# Altium schematic sheet top.SchDoc(top)
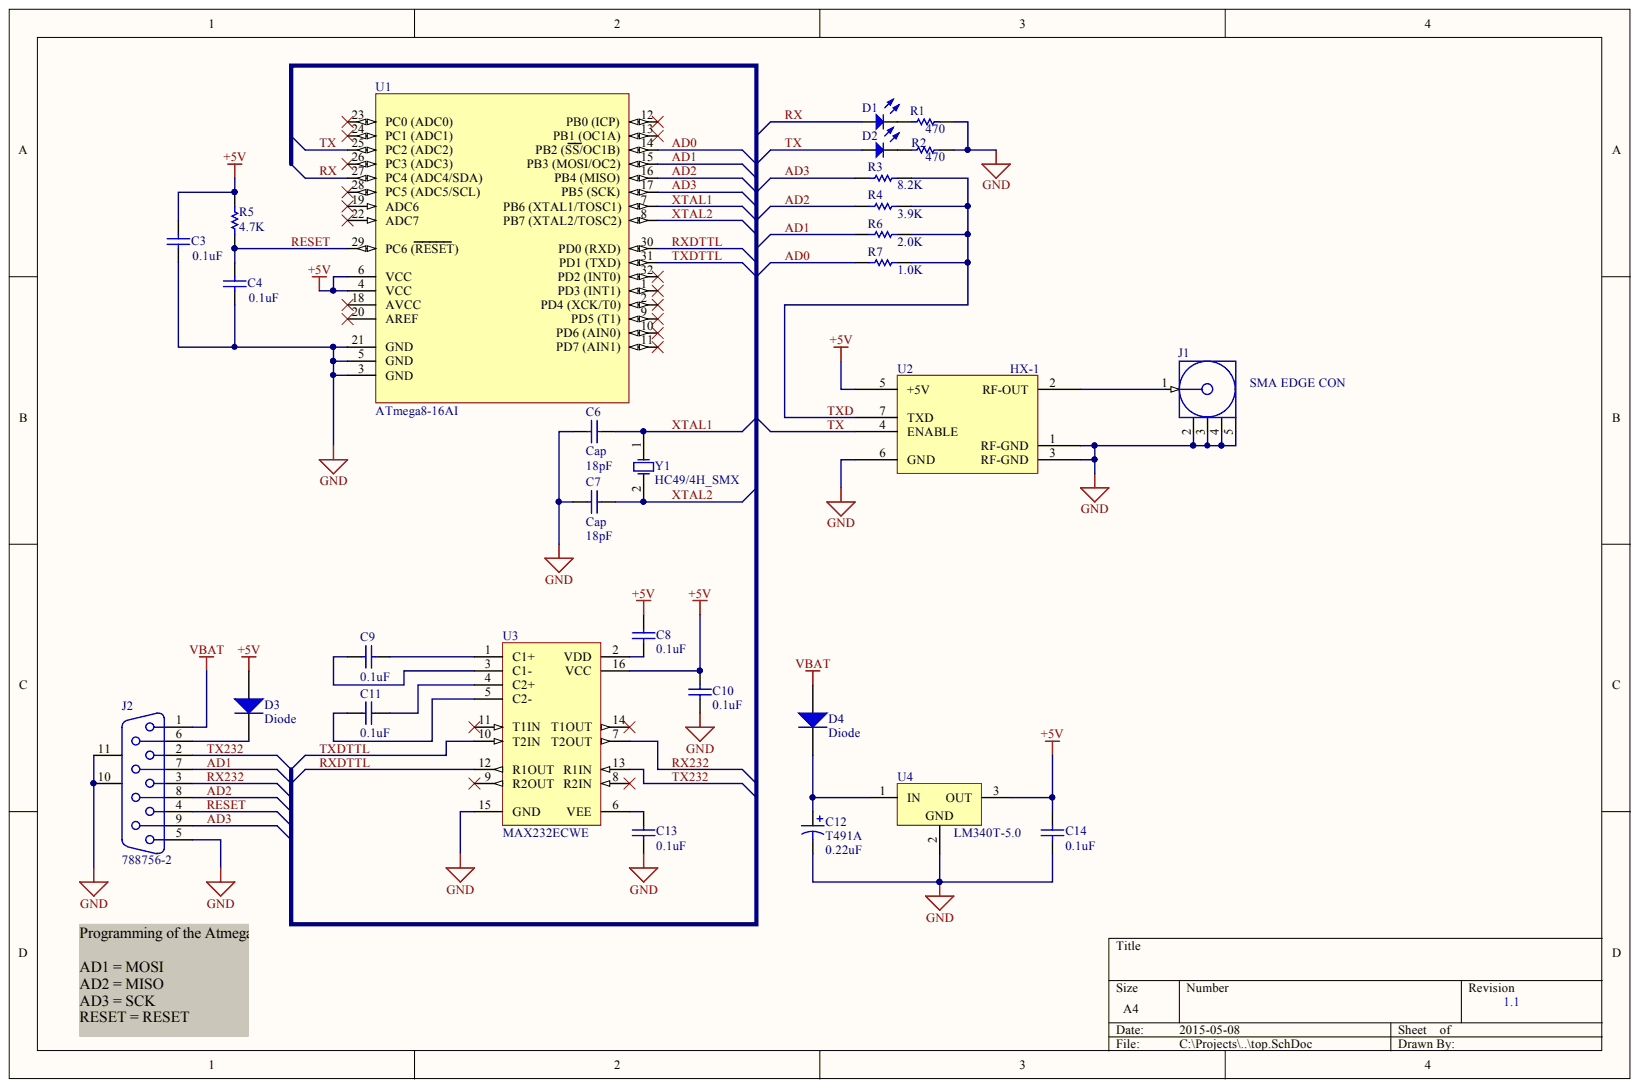
[diagram p0: top.SchDoc]

## Parts
C3  value 0.1uF  [0603]  (hidden comment: Cap Semi)
C4  value 0.1uF  [0603]  (hidden comment: Cap Semi)
C6  Cap  value 18pF  [0603]
C7  Cap  value 18pF  [0603]
C8  value 0.1uF  [0603]  (hidden comment: Cap Semi)
C9  value 0.1uF  [0603]  (hidden comment: Cap Semi)
C10  value 0.1uF  [0603]  (hidden comment: Cap Semi)
C11  value 0.1uF  [0603]  (hidden comment: Cap Semi)
C12  T491A  value 0.22uF  [A]
C13  value 0.1uF  [0603]  (hidden comment: Cap Semi)
C14  value 0.1uF  [0603]  (hidden comment: Cap Semi)
D1  LED3  [0603]  (value not drawn)
D2  LED3  [0603]  (value not drawn)
D3  Diode  [SOD-323]
D4  Diode  [SOD-323]
J1  SMA EDGE CON  [SMAEDGE]
J2  788756-2  [788756-1]
R1  value 470  [0603]  (hidden comment: Res1)
R2  value 470  [0603]  (hidden comment: Res1)
R3  value 8.2K  [0603]  (hidden comment: Res1)
R4  value 3.9K  [0603]  (hidden comment: Res1)
R5  value 4.7K  [0603]  (hidden comment: Res1)
R6  value 2.0K  [0603]  (hidden comment: Res1)
R7  value 1.0K  [0603]  (hidden comment: Res1)
U1  ATmega8-16AI  [32A_N]
U2  HX-1  [HX-1]
U3  MAX232ECWE  [WSO16_N]
U4  LM340T-5.0  [SOT223]
Y1  HC49/4H_SMX  [HC49/4H_SMX]

## Nets
+5V: C3.2 C8.1 C10.2 C14.2 D3.1 R5.1 U1.4(VCC) U1.6(VCC) U2.5(+5V) U3.16(VCC) U4.3
GND: C3.1 C4.1 C6.1 C7.1 C10.1 C12.2 C13.2 C14.1 J1.2 J1.3 J1.4 J1.5 J2.5 J2.10 J2.11 R1.1 R2.1 U1.3(GND) U1.5(GND) U1.21(GND) U2.1(RF-GND) U2.3(RF-GND) U2.6(GND) U3.15(GND) U4.2
VBAT: D4.1 J2.1
AD0: R7.1 U1.14(PB2_(SS/OC1B))
AD1: J2.7 R6.1 U1.15(PB3_(MOSI/OC2))
AD2: J2.8 R4.1 U1.16(PB4_(MISO))
AD3: J2.9 R3.1 U1.17(PB5_(SCK))
RESET: C4.2 J2.4 R5.2 U1.29(PC6_(RESET))
RX: D1.1 U1.27(PC4_(ADC4/SDA))
RX232: J2.3 U3.7(T2OUT)
RXDTTL: U1.30(PD0_(RXD)) U3.12(R1OUT)
TX: D2.1 U1.25(PC2_(ADC2)) U2.4(ENABLE)
TX232: J2.2 U3.13(R1IN)
TXD: R3.2 R4.2 R6.2 R7.2 U2.7(TXD)
TXDTTL: U1.31(PD1_(TXD)) U3.10(T2IN)
XTAL1: C6.2 U1.7(PB6_(XTAL1/TOSC1)) Y1.1
XTAL2: C7.2 U1.8(PB7_(XTAL2/TOSC2)) Y1.2
NetC8_2: C8.2 U3.2(VDD)
NetC9_1: C9.1 U3.1(C1+)
NetC9_2: C9.2 U3.3(C1-)
NetC11_1: C11.1 U3.4(C2+)
NetC11_2: C11.2 U3.5(C2-)
NetC12_1: C12.1 D4.2 U4.1
NetC13_1: C13.1 U3.6(VEE)
NetD1_2: D1.2 R1.2
NetD2_2: D2.2 R2.2
NetD3_2: D3.2 J2.6
NetJ1_1: J1.1 U2.2(RF-OUT)
N00007:

Not connected: U1 1(PD3_(INT1)) 2(PD4_(XCK/T0)) 9(PD5_(T1)) 10(PD6_(AIN0)) 11(PD7_(AIN1)) 12(PB0_(ICP)) 13(PB1_(OC1A)) 18(AVCC) 19(ADC6) 20(AREF) 22(ADC7) 23(PC0_(ADC0)) 24(PC1_(ADC1)) 26(PC3_(ADC3)) 28(PC5_(ADC5/SCL)) 32(PD2_(INT0)); U3 8(R2IN) 9(R2OUT) 11(T1IN) 14(T1OUT)

## Library data of the parts
C3 C4 C8-C11 C13 C14 = Cap Semi: Capacitor (Semiconductor SIM Model); ELFA: 65-759-63; Component Kind: Standard; LatestRevisionDate: 17-Jul-2002; LatestRevisionNote: Re-released for DXP Platform.; Library Name: Miscellaneous Devices.IntLib; PackageReference: C1206; Published: 8-Jun-2000; Signal Integrity: Cap Semi; Simulation: CAP; Publisher: Altium Limited
C6 = Cap: Capacitor; ELFA: 65-750-47; Value: 18pF; Component Kind: Standard; LatestRevisionDate: 17-Jul-2002; LatestRevisionNote: Re-released for DXP Platform.; Library Name: Miscellaneous Devices.IntLib; PackageReference: RAD-0.3; Published: 8-Jun-2000; Signal Integrity: Cap; Simulation: CAP; Publisher: Altium Limited
C7 = Cap: Capacitor; ELFA: 65-750-47; Component Kind: Standard; LatestRevisionDate: 17-Jul-2002; LatestRevisionNote: Re-released for DXP Platform.; Library Name: Miscellaneous Devices.IntLib; PackageReference: RAD-0.3; Published: 8-Jun-2000; Signal Integrity: Cap; Simulation: CAP; Publisher: Altium Limited
C12 = T491A: Solid Tantalum Chip Capacitor, Standard T491 Series - Industrial Grade; ELFA: 67-737-25; Code_JEITA: 3216-18; Component Kind: Standard; ComponentLink1Description: Manufacturer Link; ComponentLink1URL: http://www.kemet.com/; ComponentLink2Description: Datasheet; ComponentLink2URL: http://www.kemet.com/kemet/web/homepage/kechome.nsf/vapubfilesname/F3102TA.pdf/$file/F3102TA.pdf; DatasheetDocument: Mar-2001; LatestRevisionDate: 19-Mar-2004; LatestRevisionNote: Silkscreen dimensions re-sized.; Library Name: KEMET Chip Capacitor.IntLib; PackageDocument: Mar-2001; PackageReference: A; Published: 17-Jul-2002; Signal Integrity: T491A; Simulation: CAP; Publisher: Altium Limited
D1 = LED3: Typical GREEN  SiC LED; ELFA: 75-312-47; Component Kind: Standard; DatasheetDocument: 16-Apr-2002; LatestRevisionDate: 17-Dec-2003; LatestRevisionNote: PCB Footprint replaced.; PackageDocument: 16-Apr-2002; PackageReference: 3.5X2.8X1.9; Published: 8-Jun-2000; Signal Integrity: LED; Simulation: LED3; Library Name: almtracker.SCHLIB; Publisher: Altium Limited
D2 = LED3: Typical RED SiC LED; ELFA: 75-312-47; Component Kind: Standard; DatasheetDocument: 16-Apr-2002; LatestRevisionDate: 17-Dec-2003; LatestRevisionNote: PCB Footprint replaced.; PackageDocument: 16-Apr-2002; PackageReference: 3.5X2.8X1.9; Published: 8-Jun-2000; Signal Integrity: LED; Simulation: LED3; Library Name: almtracker.SCHLIB; Publisher: Altium Limited
D3 D4 = Diode: Default Diode; Manufacturer: Generic Components; Class I: Discrete; Class II: Diode - Switching; ELFA: Supply by andki; Component Kind: Standard; Library Name: Miscellaneous Devices.IntLib; Published: 8-Jun-2000; Revision: July-2002: Re-released for DXP Platform.; Signal Integrity: Diode; Simulation: DIODE; Publisher: Altium Limited
J1 = 19-46-2-TGG: SMA RF Coaxial PCB Connector, SM, Vertical Mount Socket, 50 Ohm Impedance; ELFA: Farnell; Component Kind: Standard; ComponentLink1Description: Manufacturer Link; ComponentLink1URL: http://www.chinnan.com.tw/; DatasheetVersion: rev.A-0, Jul-2002; PackageDescription: SMA Straight PCB Surface Mount Jack Receptacle; PackageReference: 19-46-2; PackageVersion: rev.A-0, Jul-2002; Published: 12-Dec-2006; Signal Integrity: RF Coaxial; Library Name: Chin Nan RF Coaxial SMA.IntLib; Publisher: Altium Limited
J2 = 788756-2: Receptacle Assembly, 9 Position, Right Angle, 8.08mm Series, Amplimite, with Screwlocks; Manufacturer: AMP; Class I: Connector; Class II: D Subminiature; Drill Size: Corresponds to nominal value.; ELFA: 44-068-15; Mating Connector: Female Screw Locks; Package Information: Latest Revision: O; PCB Layout: Complies with manufacturer's recommendation.; Positions: 9; Component Kind: Standard; Datasheet: (1-Sep-1999); Gender: Receptacle; Library Name: AMP D Subminiature AMPLIMITE 2000.IntLib; Orientation: Right Angle; Published: 15-Jun-2001; Revision: July-2002: Re-released for DXP Platform.; Shell Size: 1; Signal Integrity: D Subminiature; Publisher: Altium Limited
R1 R2 = Res1: Resistor; ELFA: 60-447-05; Component Kind: Standard; LatestRevisionDate: 17-Jul-2002; LatestRevisionNote: Re-released for DXP Platform.; Library Name: Miscellaneous Devices.IntLib; PackageReference: AXIAL-0.3; Published: 8-Jun-2000; Signal Integrity: Res; Simulation: RESISTOR; Publisher: Altium Limited
R3 = Res1: Resistor; ELFA: 60-450-09; Component Kind: Standard; LatestRevisionDate: 17-Jul-2002; LatestRevisionNote: Re-released for DXP Platform.; Library Name: Miscellaneous Devices.IntLib; PackageReference: AXIAL-0.3; Published: 8-Jun-2000; Signal Integrity: Res; Simulation: RESISTOR; Publisher: Altium Limited
R4 = Res1: Resistor; ELFA: 60-449-29; Component Kind: Standard; LatestRevisionDate: 17-Jul-2002; LatestRevisionNote: Re-released for DXP Platform.; Library Name: Miscellaneous Devices.IntLib; PackageReference: AXIAL-0.3; Published: 8-Jun-2000; Signal Integrity: Res; Simulation: RESISTOR; Publisher: Altium Limited
R5 = Res1: Resistor; ELFA: 60-449-45; Component Kind: Standard; LatestRevisionDate: 17-Jul-2002; LatestRevisionNote: Re-released for DXP Platform.; Library Name: Miscellaneous Devices.IntLib; PackageReference: AXIAL-0.3; Published: 8-Jun-2000; Signal Integrity: Res; Simulation: RESISTOR; Publisher: Altium Limited
R6 = Res1: Resistor; ELFA: 60-448-53; Component Kind: Standard; LatestRevisionDate: 17-Jul-2002; LatestRevisionNote: Re-released for DXP Platform.; Library Name: Miscellaneous Devices.IntLib; PackageReference: AXIAL-0.3; Published: 8-Jun-2000; Signal Integrity: Res; Simulation: RESISTOR; Publisher: Altium Limited
R7 = Res1: Resistor; ELFA: 60-447-88; Component Kind: Standard; LatestRevisionDate: 17-Jul-2002; LatestRevisionNote: Re-released for DXP Platform.; Library Name: Miscellaneous Devices.IntLib; PackageReference: AXIAL-0.3; Published: 8-Jun-2000; Signal Integrity: Res; Simulation: RESISTOR; Publisher: Altium Limited
U1 = ATmega8-16AI: 8-Bit AVR Microcontroller with 8K Bytes of In-System Programmable Flash Memory; Code_JEDEC: MS-026-ABA; Component Kind: Standard; ComponentLink1Description: Manufacturer Link; ComponentLink1URL: http://www.atmel.com; ComponentLink2Description: Datasheet; ComponentLink2URL: http://www.atmel.com/dyn/resources/prod_documents/doc2486.pdf; DatasheetVersion: 2486H-AVR-09/02; LatestRevisionDate: 13-Apr-2006; LatestRevisionNote: IPC-7351 Footprint Added.; Library Name: Atmel Microcontroller 8-Bit AVR.IntLib; PackageDescription: 32-Pin Thin Quad Flat Pack 0.8 mm Pitch; PackageReference: 32A; PackageVersion: revB, Oct-2001; PCB3D: ATMEGA8-32A; Published: 17-Sep-2003; Publisher: Altium Limited
U2 = HX-1: VHF Narrow Band FM High Power (300mW) Transmitter; ELFA: order by radiometrix; Component Kind: Standard; www: http://www.radiometrix.co.uk/products/hx1.htm; Library Name: almtracker.SCHLIB
U3 = MAX232ACWE: +5V Powered, Multi-Channel RS-232 Driver/Receiver; ELFA: 73-231-08; Code_IPC: SOIC127P1030-16; Code_JEDEC: MS-013-AA; Component Kind: Standard; ComponentLink1Description: Manufacturer Link; ComponentLink1URL: http://www.maxim-ic.com/; ComponentLink2Description: Datasheet; ComponentLink2URL: http://pdfserv.maxim-ic.com/en/ds/MAX220-MAX249.pdf; LatestRevisionDate: 13-Apr-2006; LatestRevisionNote: IPC-7351 Footprint Added.; Library Name: Maxim Communication Transceiver.IntLib; PackageDescription: 16-Pin Small Outline Integrated Circuit 1.27 mm Pitch; PackageReference: WSO16; PCB3D: WSO16; Published: 16-Jan-2001; Publisher: Altium Limited
U4 = LM340T-5.0: 3-Terminal Positive Fixed Voltage Regulator; ELFA: 67-288-91; Note: Heatsink surface connected to pin 2.; Code_JEDEC: TO-262-AA; Component Kind: Standard; ComponentLink1Description: Manufacturer Link; ComponentLink1URL: http://www.onsemi.com/site/products/taxonomy/0,4438,503,00.html; DatasheetVersion: 1988; Library Name: Motorola Power Mgt Voltage Regulator.IntLib; PackageDescription: TO, Thru-Hole, Vertical, Heatsink Mounted; 3 In-Line Leads; Pitch 2.54 mm; PackageReference: 221A-04; Published: 17-Jul-2002; Publisher: Altium Limited
Y1 = HC49/4H_SMX: Surface Mount Quartz Crystal; ELFA: 74-521-58; Note: PCB layout complies with manufacturer's recommendation.; Component Kind: Standard; ComponentLink1Description: Manufacturer Link; ComponentLink1URL: http://www.cmac.com/mt/; ComponentLink2Description: Datasheet; ComponentLink2URL: http://www.cmac.com/mt/databook/crystals/smd/hc49_4h_smx.pdf; DatasheetDocument: 18-Oct-1999; Library Name: C-MAC Crystal Oscillator.IntLib; PackageDocument: 18-Oct-1999; PackageReference: HC49/4H_SMX; Published: 17-Jul-2002; Publisher: Altium Limited
